# Altium schematic sheet Sheet1.SchDoc(Sheet1)
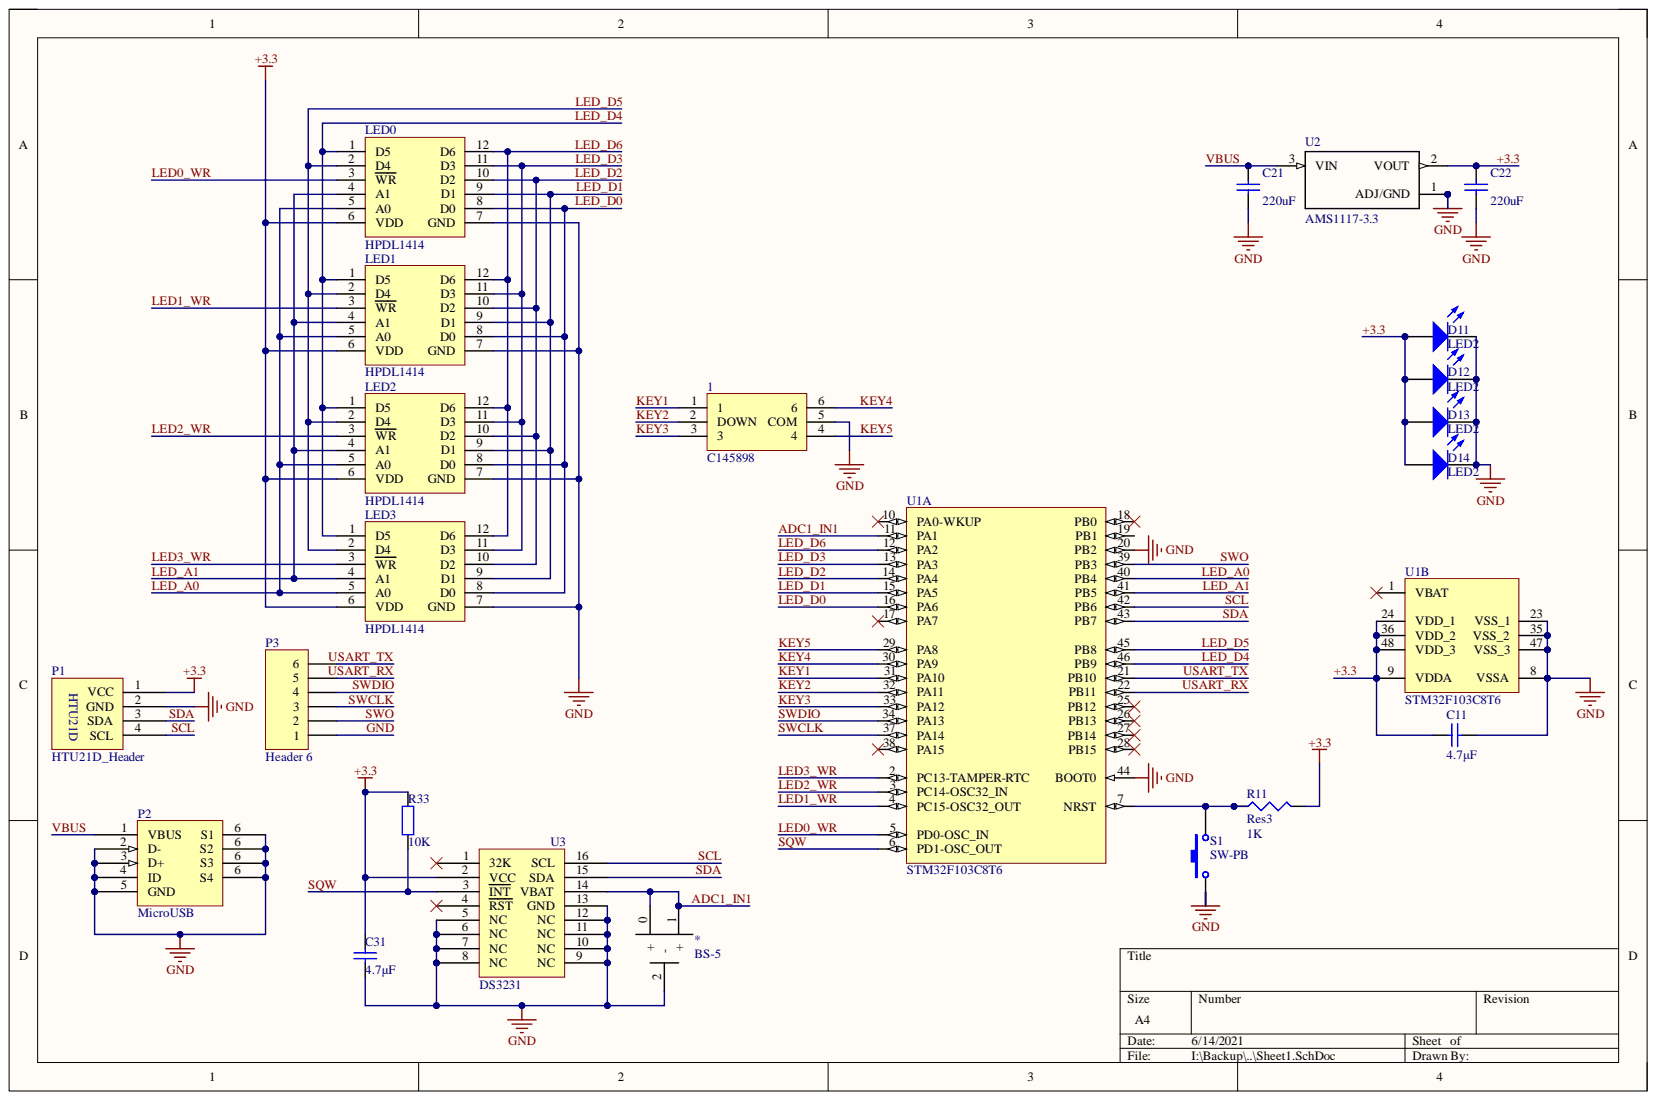
[diagram p0: Sheet1.SchDoc]

## Parts
1  C145898  [C145898]
*  BS-5  [BS-5]
C11  value 4.7μF  [C1210]  (hidden comment: Cap)
C21  value 220uF  [14-1210]  (hidden comment: Cap)
C22  value 220uF  [14-1210]  (hidden comment: Cap)
C31  value 4.7μF  [C1210]  (hidden comment: Cap)
D11  LED2  [3.2X1.6X1.1]
D12  LED2  [3.2X1.6X1.1]
D13  LED2  [3.2X1.6X1.1]
D14  LED2  [3.2X1.6X1.1]
LED0  HPDL1414  [HPDL1414]
LED1  HPDL1414  [HPDL1414]
LED2  HPDL1414  [HPDL1414]
LED3  HPDL1414  [HPDL1414]
P1  HTU21D_Header  [HEADER_4]
P2  MicroUSB  [MICRO-USB]
P3  Header 6  [HDR1X6]
R11  Res3  value 1K  [14-1210]
R33  value 10K  [C1206]  (hidden comment: Res2)
S1  SW-PB  [SPST-2]
U1  STM32F103C8T6  [STM-LQFP48_N]  (parts A,B)
U2  AMS1117-3.3  [*SOT229P700X180-4N]
U3  DS3231  [SOIC127P1030X267-16N]

## Nets
+3.3: C11.2 C22.2 C31.2 D11.1 D12.1 D13.1 D14.1 LED0.6(VDD) LED1.6(VDD) LED2.6(VDD) LED3.6(VDD) P1.1(VCC) R11.2 R33.2 U1.9(VDDA) U1.24(VDD_1) U1.36(VDD_2) U1.48(VDD_3) U2.2 U3.2(VCC)
ADC1_IN1: *.0 *.1 U1.11(PA1) U3.14(VBAT)
GND: 1.5(COM) *.2 C11.1 C21.1 C22.1 C31.1 D11.2 D12.2 D13.2 D14.2 LED0.7(GND) LED1.7(GND) LED2.7(GND) LED3.7(GND) P1.2(GND) P2.2(D-) P2.3(D+) P2.4(ID) P2.5(GND) P2.6(S4) P3.1 S1.1 U1.8(VSSA) U1.20(PB2) U1.23(VSS_1) U1.35(VSS_2) U1.44(BOOT0) U1.47(VSS_3) U2.1 U3.5(NC) U3.6(NC) U3.7(NC) U3.8(NC) U3.9(NC) U3.10(NC) U3.11(NC) U3.12(NC) U3.13(GND)
KEY1: 1.1 U1.31(PA10)
KEY2: 1.2(DOWN) U1.32(PA11)
KEY3: 1.3 U1.33(PA12)
KEY4: 1.6 U1.30(PA9)
KEY5: 1.4 U1.29(PA8)
LED0_WR: LED0.3(WR) U1.5(PD0-OSC_IN)
LED1_WR: LED1.3(WR) U1.4(PC15-OSC32_OUT)
LED2_WR: LED2.3(WR) U1.3(PC14-OSC32_IN)
LED3_WR: LED3.3(WR) U1.2(PC13-TAMPER-RTC)
LED_A0: LED0.5(A0) LED1.5(A0) LED2.5(A0) LED3.5(A0) U1.40(PB4)
LED_A1: LED0.4(A1) LED1.4(A1) LED2.4(A1) LED3.4(A1) U1.41(PB5)
LED_D0: LED0.8(D0) LED1.8(D0) LED2.8(D0) LED3.8(D0) U1.16(PA6)
LED_D1: LED0.9(D1) LED1.9(D1) LED2.9(D1) LED3.9(D1) U1.15(PA5)
LED_D2: LED0.10(D2) LED1.10(D2) LED2.10(D2) LED3.10(D2) U1.14(PA4)
LED_D3: LED0.11(D3) LED1.11(D3) LED2.11(D3) LED3.11(D3) U1.13(PA3)
LED_D4: LED0.1(D5) LED1.1(D5) LED2.1(D5) LED3.1(D5) U1.46(PB9)
LED_D5: LED0.2(D4) LED1.2(D4) LED2.2(D4) LED3.2(D4) U1.45(PB8)
LED_D6: LED0.12(D6) LED1.12(D6) LED2.12(D6) LED3.12(D6) U1.12(PA2)
SCL: P1.4(SCL) U1.42(PB6) U3.16(SCL)
SDA: P1.3(SDA) U1.43(PB7) U3.15(SDA)
SQW: R33.1 U1.6(PD1-OSC_OUT) U3.3(INT)
SWCLK: P3.3 U1.37(PA14)
SWDIO: P3.4 U1.34(PA13)
SWO: P3.2 U1.39(PB3)
USART_RX: P3.5 U1.22(PB11)
USART_TX: P3.6 U1.21(PB10)
VBUS: C21.2 P2.1(VBUS) U2.3
NetR11_1: R11.1 S1.2 U1.7(NRST)

Not connected: U1 1(VBAT) 10(PA0-WKUP) 17(PA7) 18(PB0) 19(PB1) 25(PB12) 26(PB13) 27(PB14) 28(PB15) 38(PA15); U3 1(32K) 4(RST)

## Library data of the parts
C11 C21 C22 C31 = Cap: Capacitor; Component Kind: Standard; LatestRevisionDate: 17-Jul-2002; LatestRevisionNote: Re-released for DXP Platform.; Library Name: Miscellaneous Devices.IntLib; PackageDescription: Radial Cap, Thru-Hole; 2 Leads; 0.3 in Pin Spacing; PackageReference: RAD-0.3; Published: 8-Jun-2000; Signal Integrity: Cap; Simulation: CAP; Publisher: Altium Limited
D11-D14 = LED2: Typical RED, GREEN, YELLOW, AMBER GaAs LED; Component Kind: Standard; LatestRevisionDate: 17-Jul-2002; LatestRevisionNote: Re-released for DXP Platform.; Library Name: Miscellaneous Devices.IntLib; PackageDescription: SM LED; 2 Flat Leads; PackageReference: 3.2X1.6X1.1; PackageVersion: Feb-2002; Published: 8-Jun-2000; Simulation: LED2; Publisher: Altium Limited
P3 = Header 6: Header, 6-Pin; Component Kind: Standard; LatestRevisionDate: 17-Jul-2002; LatestRevisionNote: Re-released for DXP Platform.; Library Name: Miscellaneous Connectors.IntLib; Signal Integrity: Connector; Publisher: Altium Limited
R11 = Res3: Resistor; Component Kind: Standard; LatestRevisionDate: 29-May-2009; LatestRevisionNote: IPC-7351 Footprint Added.; Library Name: Miscellaneous Devices.IntLib; PackageDescription: Chip Resistor; PackageReference: J1-0603; Published: 8-Jun-2000; Signal Integrity: Res; Simulation: RESISTOR; Publisher: Altium Limited
R33 = Res2: Resistor; Component Kind: Standard; LatestRevisionDate: 17-Jul-2002; LatestRevisionNote: Re-released for DXP Platform.; Library Name: Miscellaneous Devices.IntLib; PackageDescription: Axial Device, Thru-Hole; 2 Leads; 0.4 in Pin Spacing; PackageReference: AXIAL-0.4; Published: 8-Jun-2000; Signal Integrity: Res; Simulation: RESISTOR; Publisher: Altium Limited
S1 = SW-PB: Switch; Component Kind: Standard; LatestRevisionDate: 17-Jul-2002; LatestRevisionNote: Re-released for DXP Platform.; Library Name: Miscellaneous Devices.IntLib; PackageDescription: Switch; 2 Leads; PackageReference: SPST-2; Published: 24-Mar-1999; Publisher: Altium Limited
U1 = STM32F103C8T6: ARM Cortex-M3 32-bit MCU, 64 KB Flash, 20 KB Internal RAM, 37 I/Os, 48-pin LQFP, -40 to 85 degC, Tray; A/D Converter: 2x12-bit (10 Channel); FLASH Size (Prog) (kB): 64; I/Os (High Current): 37; Internal RAM Size (kB): 20; Operating Frequency(F) (Processor speed) (MHz): 72; Supply Voltage(Vcc) min (V): 2; Component Kind: Standard; ComponentLink1Description: Manufacturer URL; ComponentLink1URL: http://www.st.com/web/en/home.html; ComponentLink2Description: Datasheet; ComponentLink2URL: http://www.st.com/st-web-ui/static/active/en/resource/technical/document/datasheet/CD00161566.pdf; ComponentLink3Description: Package Specification; ComponentLink3URL: http://www.st.com/st-web-ui/static/active/en/resource/technical/document/datasheet/DM00058181.pdf; Core: ARM Cortex-M3; DatasheetVersion: Rev. 16; Library Name: STMicroelectronics STM32 F1.IntLib; Mounting Technology: Surface Mount; PackageDescription: 48-Pin Low Profile Quad Flat Package, 7 x 7 mm Body, 0.5 mm Pitch; PackageReference: LQFP48; PackageVersion: Rev. 6, 06/2013; Packing: Tray; PartNumber: STM32F103C8T6; Supplier 1: Digi-Key; Supplier 2: Farnell; Supplier 3: Newark; Supplier 4: Newark; Supplier 5: Mouser; Supplier Part Number 1: 497-6063-ND; Supplier Part Number 2: 1447637; Supplier Part Number 3: 59M2182; Supplier Part Number 4: 57P1222; Supplier Part Number 5: 511-STM32F103C8T6
U2 = AMS1117-3.3: Sot223/Pkg 1-Amp 3.3-Volt Low Drop Out Voltage Regulatator; MF: Advanced Monolithic Systems; Availability: Unavailable; Component Kind: Standard; MP: AMS1117-3.3; Package: None; Price: None; SnapEDA_Link: https://www.snapeda.com/parts/AMS1117-3.3/Advanced%20Monolithic%20Systems/view-part/?ref=snap; Library Name: OTakuCal.SchLib
1 = C145898: Component Kind: Standard; Library Name: OTakuCal.SchLib
* = BS-5: Component Kind: Standard; Library Name: OTakuCal.SchLib
LED0-LED3 = HPDL1414: Component Kind: Standard; Library Name: OTakuCal.SchLib
P1 = HTU21D_Header: Component Kind: Standard; Library Name: OTakuCal.SchLib
P2 = MicroUSB: Component Kind: Standard; Library Name: OTakuCal.SchLib
U3 = DS3231: Component Kind: Standard; Library Name: OTakuCal.SchLib
